# Altium schematic sheet probe.SchDoc(probe)
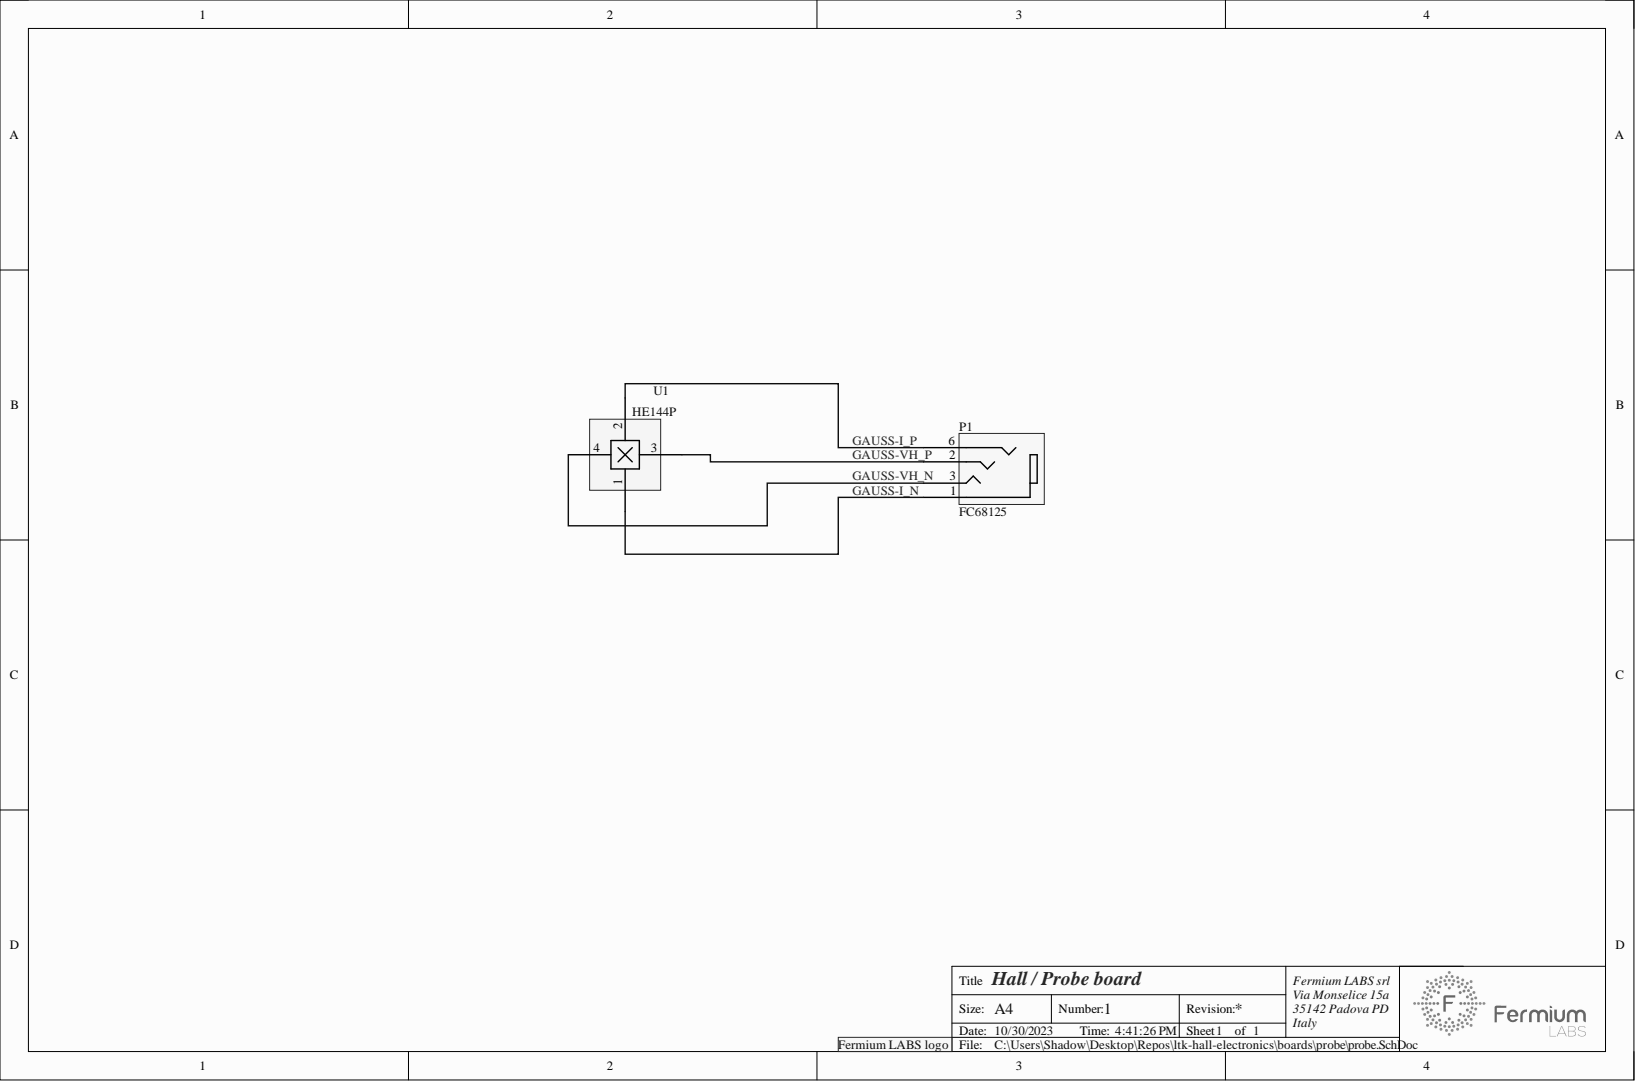
[diagram p0: probe.SchDoc]

## Parts
logo  fermiumlabs-logo  [frm-med]  (value not drawn)  (no BOM)
P1  (no value)  [FC68125]
U1  (no value)  [HE144P-buried]

## Nets
GAUSS-I_N: P1.1 U1.1
GAUSS-I_P: P1.6 U1.2
GAUSS-VH_N: P1.3 U1.4
GAUSS-VH_P: P1.2 U1.3

## Library data of the parts
P1 = FC68125: Manufacturer: CLIFF; Connector: socket; Connector type: Jack 3,5mm; Electrical mounting: THT; MinAmount: 2; Multiples: 1; Number of ways: 4; Pads: 5 THT; SuppliedAmount: 0; Unit: pcs; Category: Jack connectors; Component Kind: Standard; ComponentLink1Description: http://static.tme.eu/products_pics/9/3/a/93a060f269bb569398921100f84c519a/360179.jpg; ComponentLink1URL: http://static.tme.eu/products_pics/9/3/a/93a060f269bb569398921100f84c519a/360179.jpg; Depth: 15.1mm; Height: 6mm; Library Name: custom_components.SchLib; Manufacturer Part Number: FC68125; Price Type: NET; Pricing 1: 2=0.41, 20=0.37, 100=0.33, 500=0.28 (USD); Stock 1: 1312; Supplier 1: TME; Supplier Part Number 1: FC68125; Weight: 0.942; Width: 7.1mm
U1 = he144p: Manufacturer: Asensor; Pads: 4 THT; Component Kind: Standard; Library Name: custom_components.SchLib; Manufacturer Part Number: HE144P; Supplier 1: Asensor; Supplier Part Number 1: HE144P
logo = fermiumlabs-logo: Library Name: custom_components.SchLib
